# Altium schematic sheet DE-130624.SchDoc(DE-130624)
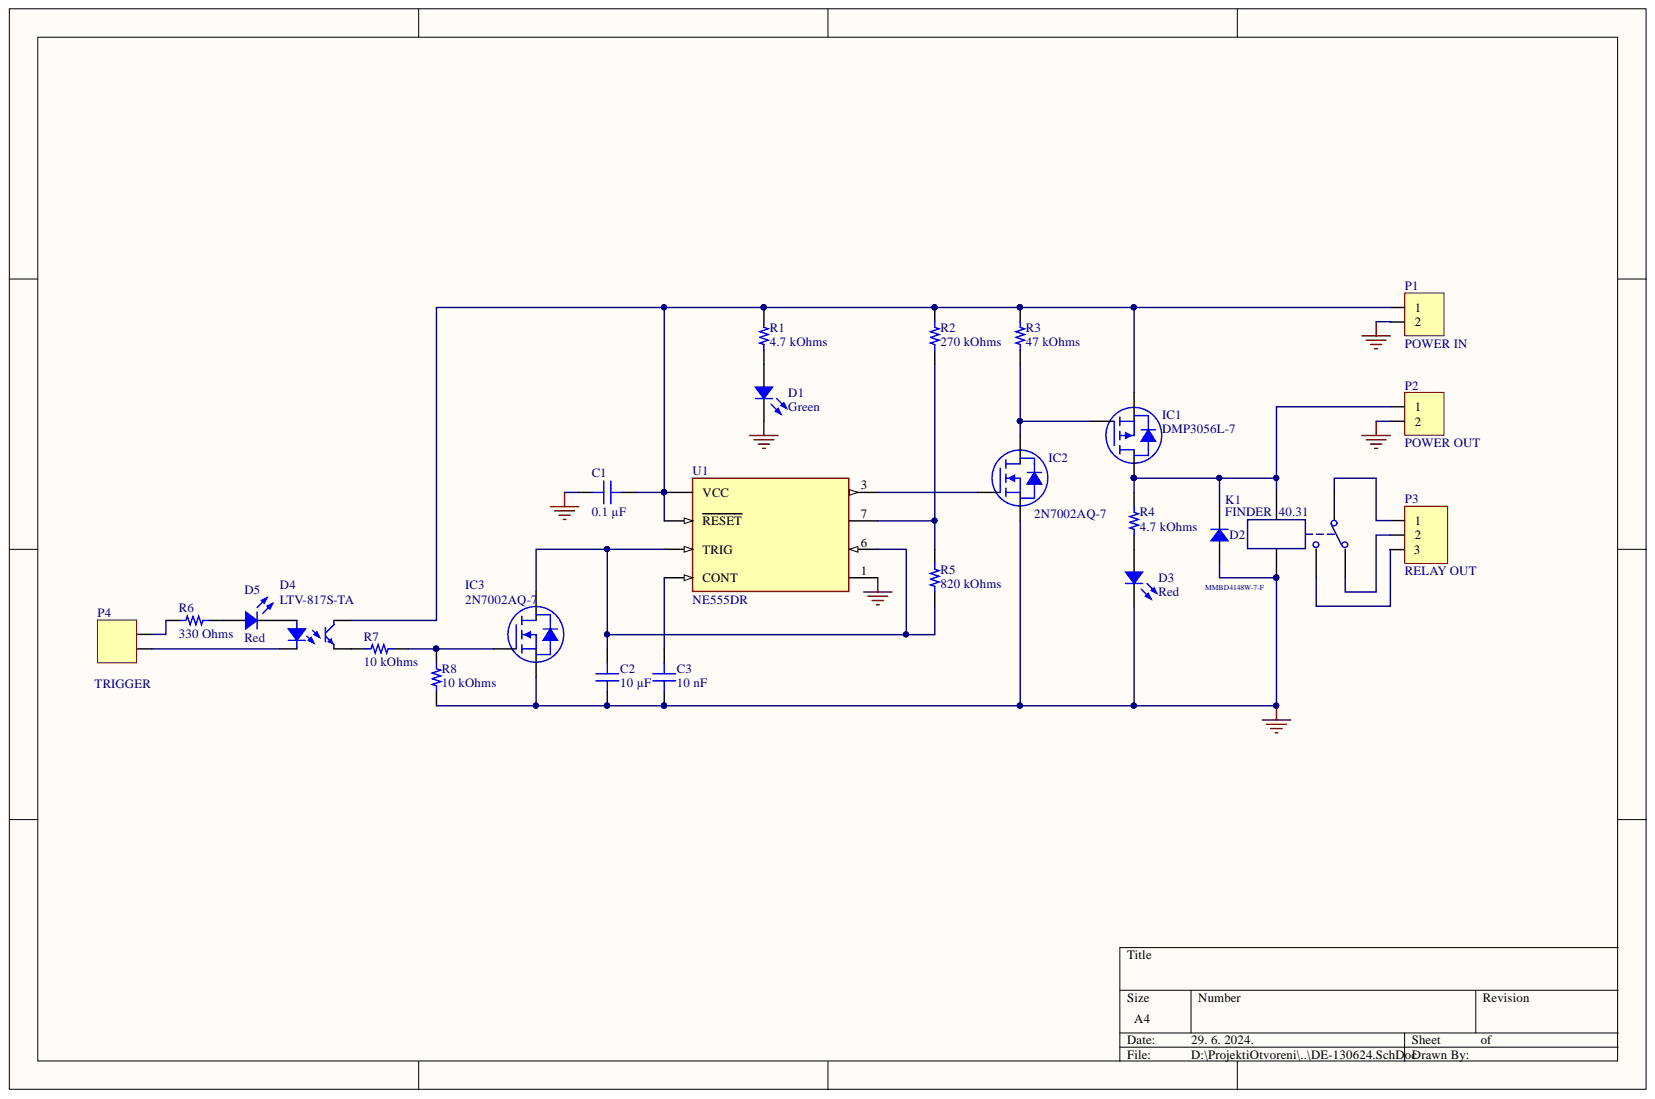
[diagram p0: DE-130624.SchDoc]

## Parts
C1  0.1 µF  [CAP 0603_1608]
C2  10 µF  [CAP 0805_2012]
C3  10 nF  [CAP 0603_1608]
D1  Green  [1.6X0.8X0.8]
D2  MMBD4148W-7-F  [DIODES SOT-323-3]
D3  Red  [1.6X0.8X0.8]
D4  LTV-817S-TA  [LITEON LTV-817]
D5  Red  [1.6X0.8X0.8]
IC1  DMP3056L-7  [DIODES SOT-23-3]
IC2  2N7002AQ-7  [DIODES SOT-23-3]
IC3  2N7002AQ-7  [DIODES SOT-23-3]
K1  FINDER  40.31  [FINDER 40.31]
P1  POWER IN  [TERMINAL BLOK 2]
P2  POWER OUT  [TERMINAL BLOK 2]
P3  RELAY OUT  [TERMINAL BLOK 3]
P4  TRIGGER  [TERMINAL BLOK 2]
R1  4.7 kOhms  [RES 0805_2012]
R2  270 kOhms  [RES 0805_2012]
R3  47 kOhms  [RES 0805_2012]
R4  4.7 kOhms  [RES 0805_2012]
R5  820 kOhms  [RES 0805_2012]
R6  330 Ohms  [RES 0805_2012]
R7  10 kOhms  [RES 0805_2012]
R8  10 kOhms  [RES 0805_2012]
U1  NE555DR  [FP-D0008A-IPC_B]

## Nets
GND: C1.1 C2.1 C3.1 D1.2 D2.1 D3.2 IC2.2 IC3.2 K1.2 P1.2 P2.2 R8.1 U1.1
NetC1_2: C1.2 D4.4 IC1.2 P1.1 R1.2 R2.2 R3.2 U1.4(RESET) U1.8(VCC)
NetC2_2: C2.2 IC3.3 R5.1 U1.2(TRIG) U1.6
NetC3_2: C3.2 U1.5(CONT)
NetD1_1: D1.1 R1.1
NetD2_2: D2.2 IC1.3 K1.1 P2.1 R4.2
NetD3_1: D3.1 R4.1
NetD4_1: D4.1 D5.2
NetD4_2: D4.2 P4.1
NetD4_3: D4.3 R7.2
NetD5_1: D5.1 R6.2
NetIC1_1: IC1.1 IC2.3 R3.1
NetIC2_1: IC2.1 U1.3
NetIC3_1: IC3.1 R7.1 R8.2
NetK1_3: K1.3 P3.1
NetK1_4: K1.4 P3.3
NetK1_5: K1.5 P3.2
NetP4_2: P4.2 R6.1
NetR2_1: R2.1 R5.2 U1.7

## Library data of the parts
C1 = C0603C104K5RAC7411: CAP CER 0.1UF 50V X7R 0603; manufacturer: KEMET; Tolerance: ±10%; Database Table Name: Capacitors - Ceramic - 0603; Voltage - Rated: 50V; Value: 0.1 µF; Thickness (Max): 0.035" (0.90mm); Temperature Coefficient: X7R; Supplier Part Number 1: 399-C0603C104K5RAC7411CT-ND; Supplier 1: DigiKey; Size / Dimension: 0.063" L x 0.031" W (1.60mm x 0.80mm); series: SMD Comm X7R; price: 0.01; Part Status: Active; Part Number: C0603C104K5RAC7411; partid: 2233; packaging: TapeAndReel; Package / Case: 0603 (1608 Metric); Operating Temperature: -55°C ~ 125°C; Mounting Type: Surface Mount, MLCC; Minimum Order: 1; Lifecycle Status: Active; Library Name: Celestial Altium Library - altium_library.DbLib; lastupdated: 9. 2. 2024. 20:10:30; Device Package: 0603 (1608 Metric); componentlink2url: https://www.digikey.com/en/products/detail/kemet/C0603C104K5RAC7411/3317013; componentlink2description: DigiKey Link; componentlink1url: https://api.kemet.com/component-edge/download/datasheet/C0603C104K5RAC7411.pdf; componentlink1description: Datasheet; Component Kind: Standard; Capacitance: 0.1 µF; Applications: Bypass, Decoupling
C2 = GMK212BBJ106KG-T: CAP CER 10UF 35V X5R 0805; manufacturer: Taiyo Yuden; Tolerance: ±10%; Database Table Name: Capacitors - Ceramic - 0805; Voltage - Rated: 35V; Value: 10 µF; Thickness (Max): 0.057" (1.45mm); Temperature Coefficient: X5R; Supplier Part Number 1: 587-4893-1-ND; Supplier 1: DigiKey; Size / Dimension: 0.079" L x 0.049" W (2.00mm x 1.25mm); series: M; price: 0.15; Part Status: Active; Part Number: GMK212BBJ106KG-T; partid: 11657; packaging: TapeAndReel; Package / Case: 0805 (2012 Metric); Operating Temperature: -55°C ~ 85°C; Mounting Type: Surface Mount, MLCC; Minimum Order: 1; Lifecycle Status: Active; Library Name: Celestial Altium Library - altium_library.DbLib; lastupdated: 9. 2. 2024. 20:15:45; Device Package: 0805 (2012 Metric); componentlink2url: https://www.digikey.com/en/products/detail/taiyo-yuden/GMK212BBJ106KG-T/6563486; componentlink2description: DigiKey Link; componentlink1url: http://www.yuden.co.jp/productdata/catalog/mlcc06_e.pdf; componentlink1description: Datasheet; Component Kind: Standard; Capacitance: 10 µF; Applications: SMPS Filtering
C3 = C0603X103K1RAC3316: CAP CER 0603 10NF 100V X7R; manufacturer: KEMET; Tolerance: ±10%; Database Table Name: Capacitors - Ceramic - 0603; Capacitance: 10000 pF; Voltage - Rated: 100V; Value: 10 nF; Thickness (Max): 0.037" (0.95mm); Temperature Coefficient: X7R; Supplier Part Number 1: 399-C0603X103K1RAC3316CT-ND; Supplier 1: DigiKey; Size / Dimension: 0.063" L x 0.031" W (1.60mm x 0.80mm); series: SMD Auto X7R VW80808; Ratings: AEC-Q200; price: 0.07; Part Status: Active; Part Number: C0603X103K1RAC3316; partid: 8591; packaging: TapeAndReel; Package / Case: 0603 (1608 Metric); Operating Temperature: -55°C ~ 125°C; Mounting Type: Surface Mount, MLCC; Minimum Order: 1; Lifecycle Status: Active; Library Name: Celestial Altium Library - altium_library.DbLib; lastupdated: 9. 2. 2024. 20:10:22; Features: Soft Termination; Device Package: 0603 (1608 Metric); componentlink2url: https://www.digikey.com/en/products/detail/kemet/C0603X103K1RAC3316/10315782; componentlink2description: DigiKey Link; componentlink1url: https://api.kemet.com/component-edge/download/datasheet/C0603X103K1RAC3316.pdf; componentlink1description: Datasheet; Component Kind: Standard; Applications: Automotive, Boardflex Sensitive
D1 = LTST-C170KGKT: LED GREEN CLEAR SMD; Manufacturer: Lite-On Inc.; Wavelength - Peak: 574nm; Wavelength - Dominant: 571nm; Voltage - Forward (Vf) (Typ): 2V; Viewing Angle: 130°; Millicandela Rating: 35mcd; Lens Style: Rectangle with Flat Top; Lens Size: 1.40mm x 1.25mm; Lens Color: Colorless; Database Table Name: LED - Indication; Current - Test: 20mA; Configuration: Standard; Value: Green; Supplier Part Number 1: 160-1414-1-ND; Supplier 1: DigiKey; Size / Dimension: 2.00mm L x 1.25mm W; Series: -; Price: 0.06; Part Status: Active; Part Number: LTST-C170KGKT; PartId: 285; Packaging: TapeAndReel; Package / Case: 0805 (2012 Metric); Mounting Type: Surface Mount; Minimum Order: 1; Lifecycle Status: Active; Library Name: Celestial Altium Library - altium_library.DbLib; Lens Transparency: Clear; LastUpdated: 3. 6. 2024. 20:00:29; Height (Max): 1.10mm; Device Package: 0805 (2012 Metric); ComponentLink2URL: http://digikey.com/product-detail/en/lite-on-inc/LTST-C170KGKT/160-1414-1-ND/386776; ComponentLink2Description: DigiKey Link; ComponentLink1URL: http://optoelectronics.liteon.com/upload/download/DS22-2000-073/LTST-C170KGKT.pdf; ComponentLink1Description: Datasheet; Component Kind: Standard; Color: Green
D2 = MMBD4148W-7-F: DIODE GEN PURP 75V 150MA SOT323; Manufacturer: Diodes Incorporated; Voltage - Forward (Vf) (Max) @ If: 1.25 V @ 150 mA; Speed: Small Signal =< 200mA (Io), Any Speed; Reverse Recovery Time (trr): 4 ns; Diode Type: Standard; Database Table Name: Diodes - Rectifiers - Single; Current - Reverse Leakage @ Vr: 1 µA @ 75 V; Capacitance @ Vr, F: 2pF @ 0V, 1MHz; Voltage - DC Reverse (Vr) (Max): 75 V; Supplier Part Number 1: MMBD4148W-FDICT-ND; Supplier Device Package: SOT-323; Supplier 1: DigiKey; Series: -; Price: 0.02; Part Status: Active; Part Number: MMBD4148W-7-F; PartId: 13; Packaging: TapeAndReel; Package / Case: SC-70, SOT-323; Operating Temperature - Junction: -65°C ~ 150°C; Mounting Type: Surface Mount; Minimum Order: 1; Lifecycle Status: Active; Library Name: Celestial Altium Library - altium_library.DbLib; LastUpdated: 3. 6. 2024. 20:01:39; Current - Average Rectified (Io): 150mA; ComponentLink2URL: https://www.digikey.com/en/products/detail/diodes-incorporated/MMBD4148W-7-F/768787; ComponentLink2Description: DigiKey Link; ComponentLink1URL: https://www.diodes.com/assets/Datasheets/ds30094.pdf; ComponentLink1Description: Datasheet; Component Kind: Standard
D3 D5 = LTST-C170KAKT: LED RED CLEAR SMD; Manufacturer: Lite-On Inc.; Wavelength - Peak: 621nm; Wavelength - Dominant: 615nm; Voltage - Forward (Vf) (Typ): 2V; Viewing Angle: 130°; Millicandela Rating: 54mcd; Lens Style: Rectangle with Flat Top; Lens Size: 1.40mm x 1.25mm; Lens Color: Colorless; Database Table Name: LED - Indication; Current - Test: 20mA; Configuration: Standard; Value: Red; Supplier Part Number 1: 160-1412-1-ND; Supplier 1: DigiKey; Size / Dimension: 2.00mm L x 1.25mm W; Series: -; Price: 0.07; Part Status: Active; Part Number: LTST-C170KAKT; PartId: 305; Packaging: TapeAndReel; Package / Case: 0805 (2012 Metric); Mounting Type: Surface Mount; Minimum Order: 1; Lifecycle Status: Active; Library Name: Celestial Altium Library - altium_library.DbLib; Lens Transparency: Clear; LastUpdated: 3. 6. 2024. 20:00:07; Height (Max): 1.10mm; Device Package: 0805 (2012 Metric); ComponentLink2URL: http://digikey.com/product-detail/en/lite-on-inc/LTST-C170KAKT/160-1412-1-ND/386772; ComponentLink2Description: DigiKey Link; ComponentLink1URL: http://optoelectronics.liteon.com/upload/download/DS-22-99-0182/LTST-C170KAKT.pdf; ComponentLink1Description: Datasheet; Component Kind: Standard; Color: Red
D4 = LTV-817S-TA: OPTOISOLATR 5KV TRANSISTOR 4-SMD; Manufacturer: Lite-On Inc.; Voltage - Output (Max): 35V; Voltage - Isolation: 5000Vrms; Voltage - Forward (Vf) (Typ): 1.2V; Vce Saturation (Max): 200mV; Rise / Fall Time (Typ): 4µs, 3µs; Number of Channels: 1; Input Type: DC; Database Table Name: Optoisolators - Transistor Photovoltaic; Current Transfer Ratio (Min): 50% @ 5mA; Current Transfer Ratio (Max): 600% @ 5mA; Current - Output / Channel: 50mA; Supplier Part Number 1: 160-2038-1-ND; Supplier Device Package: 4-SMD; Supplier 1: DigiKey; Series: -; Price: 0.12; Part Status: Active; Part Number: LTV-817S-TA; Packaging: TapeAndReel; Package / Case: 4-SMD, Gull Wing; Output Type: Transistor; Operating Temperature: -30°C ~ 110°C; Mounting Type: Surface Mount; Minimum Order: 1; Lifecycle Status: Active; Library Name: Celestial Altium Library - altium_library.DbLib; LastUpdated: 12. 1. 2020. 21:59:02; Device Package: 4-SMD, Gull Wing; Current - DC Forward (If) (Max): 50mA; ComponentLink2URL: http://digikey.com/product-detail/en/lite-on-inc/LTV-817S-TA/160-2038-1-ND/3711420; ComponentLink2Description: DigiKey Link; ComponentLink1URL: http://optoelectronics.liteon.com/upload/download/DS-70-96-0016/LTV-8X7%20series%20201610%20.pdf; ComponentLink1Description: Datasheet; Component Kind: Standard
IC1 = DMP3056L-7: MOSFET P-CH 30V 4.3A SOT23; Manufacturer: Diodes Incorporated; Vgs(th) (Max) @ Id: 2.1V @ 250µA; Vgs (Max): ±25V; Rds On (Max) @ Id, Vgs: 50mOhm @ 6A, 10V; Power Dissipation (Max): 1.38W (Ta); Input Capacitance (Ciss) (Max) @ Vds: 642 pF @ 25 V; Gate Charge (Qg) (Max) @ Vgs: 11.8 nC @ 10 V; FET Type: P-Channel; Drive Voltage (Max Rds On,  Min Rds On): 4.5V, 10V; Database Table Name: MOSFET - Single - P-Ch; Current - Continuous Drain (Id) @ 25°C: 4.3A (Ta); Technology: MOSFET (Metal Oxide); Supplier Part Number 1: DMP3056L-7DICT-ND; Supplier Device Package: SOT-23-3; Supplier 1: DigiKey; Series: -; Price: 0.09; Part Status: Active; Part Number: DMP3056L-7; Packaging: TapeAndReel; Package / Case: TO-236-3, SC-59, SOT-23-3; Operating Temperature: -55°C ~ 150°C (TJ); Mounting Type: Surface Mount; Minimum Order: 1; Lifecycle Status: Active; Library Name: Celestial Altium Library - altium_library.DbLib; LastUpdated: 3. 6. 2024. 20:15:20; Drain to Source Voltage (Vdss): 30 V; ComponentLink2URL: https://www.digikey.com/en/products/detail/diodes-incorporated/DMP3056L-7/5126843; ComponentLink2Description: DigiKey Link; ComponentLink1URL: https://www.diodes.com/assets/Datasheets/DMP3056L.pdf; ComponentLink1Description: Datasheet; Component Kind: Standard
IC2 IC3 = 2N7002AQ-7: MOSFET N-CH 60V 180MA SOT23; Manufacturer: Diodes Incorporated; Vgs(th) (Max) @ Id: 2V @ 250µA; Vgs (Max): ±20V; Rds On (Max) @ Id, Vgs: 5Ohm @ 115mA, 10V; Power Dissipation (Max): 370mW (Ta); Input Capacitance (Ciss) (Max) @ Vds: 23 pF @ 25 V; FET Type: N-Channel; Drive Voltage (Max Rds On,  Min Rds On): 5V; Database Table Name: MOSFET - Single - N-Ch; Current - Continuous Drain (Id) @ 25°C: 180mA (Ta); Technology: MOSFET (Metal Oxide); Supplier Part Number 1: 2N7002AQ-7DICT-ND; Supplier Device Package: SOT-23-3; Supplier 1: DigiKey; Series: -; Price: 0.03; Part Status: Active; Part Number: 2N7002AQ-7; Packaging: TapeAndReel; Package / Case: TO-236-3, SC-59, SOT-23-3; Operating Temperature: -55°C ~ 150°C (TJ); Mounting Type: Surface Mount; Minimum Order: 1; Lifecycle Status: Active; Library Name: Celestial Altium Library - altium_library.DbLib; LastUpdated: 3. 6. 2024. 20:15:18; Drain to Source Voltage (Vdss): 60 V; ComponentLink2URL: https://www.digikey.com/en/products/detail/diodes-incorporated/2N7002AQ-7/6186937; ComponentLink2Description: DigiKey Link; ComponentLink1URL: https://www.diodes.com/assets/Datasheets/2N7002AQ.pdf; ComponentLink1Description: Datasheet; Component Kind: Standard
K1 = FINDER RELAY 40.31: Library Name: DE-220817.SchLib; Component Kind: Standard
P1 P2 P4 = TERMINAL BLOK 2: Terminal blok konektor 3,5 mm; Library Name: DE-220817.SchLib; Component Kind: Standard
P3 = TERMINAL BLOK 3: 3 pinski terminal blok konektor; Library Name: DE-220817.SchLib; Component Kind: Standard
R1 R4 = AC0805FR-7W4K7L: RES 4.7K OHM 1% 1/4W 0805; Manufacturer: Yageo; Temperature Coefficient: ±100ppm/°C; Power (Watts): 0.25W, 1/4W; Database Table Name: Resistors - Surface Mount - 0805; Value: 4.7 kOhms; Tolerance: ±1%; Supplier Part Number 1: 13-AC0805FR-7W4K7LCT-ND; Supplier Device Package: 0805; Supplier 1: DigiKey; Size / Dimension: 0.079" L x 0.049" W (2.00mm x 1.25mm); Series: AC; Resistance: 4.7 kOhms; Ratings: AEC-Q200; Price: 0.01; Part Status: Active; Part Number: AC0805FR-7W4K7L; PartId: 77750; Packaging: TapeAndReel; Package / Case: 0805 (2012 Metric); Operating Temperature: -55°C ~ 155°C; Number of Terminations: 2; Minimum Order: 1; Lifecycle Status: Active; Library Name: Celestial Altium Library - altium_library.DbLib; LastUpdated: 8. 2. 2024. 21:00:02; Height - Seated (Max): 0.024" (0.60mm); Features: Automotive AEC-Q200, Moisture Resistant; Device Package: 0805 (2012 Metric); Composition: Thick Film; ComponentLink2URL: https://www.digikey.com/en/products/detail/yageo/AC0805FR-7W4K7L/16989051; ComponentLink2Description: DigiKey Link; ComponentLink1URL: https://www.yageo.com/upload/media/product/productsearch/datasheet/rchip/PYu-AC_51_RoHS_L_9.pdf; ComponentLink1Description: Datasheet; Component Kind: Standard
R2 = AC0805FR-07270KL: RES SMD 270K OHM 1% 1/8W 0805; Manufacturer: Yageo; Temperature Coefficient: ±100ppm/°C; Power (Watts): 0.125W, 1/8W; Database Table Name: Resistors - Surface Mount - 0805; Value: 270 kOhms; Tolerance: ±1%; Supplier Part Number 1: 13-AC0805FR-07270KLCT-ND; Supplier Device Package: 0805; Supplier 1: DigiKey; Size / Dimension: 0.079" L x 0.049" W (2.00mm x 1.25mm); Series: AC; Resistance: 270 kOhms; Ratings: AEC-Q200; Price: 0.01; Part Status: Active; Part Number: AC0805FR-07270KL; PartId: 61828; Packaging: TapeAndReel; Package / Case: 0805 (2012 Metric); Operating Temperature: -55°C ~ 155°C; Number of Terminations: 2; Minimum Order: 1; Lifecycle Status: Active; Library Name: Celestial Altium Library - altium_library.DbLib; LastUpdated: 8. 2. 2024. 21:00:40; Height - Seated (Max): 0.024" (0.60mm); Features: Automotive AEC-Q200, Moisture Resistant; Device Package: 0805 (2012 Metric); Composition: Thick Film; ComponentLink2URL: https://www.digikey.com/en/products/detail/yageo/AC0805FR-07270KL/5896709; ComponentLink2Description: DigiKey Link; ComponentLink1URL: https://www.yageo.com/upload/media/product/productsearch/datasheet/rchip/PYu-AC_51_RoHS_L_8.pdf; ComponentLink1Description: Datasheet; Component Kind: Standard
R3 = AC0805FR-0747KL: RES SMD 47K OHM 1% 1/8W 0805; Manufacturer: Yageo; Temperature Coefficient: ±100ppm/°C; Power (Watts): 0.125W, 1/8W; Database Table Name: Resistors - Surface Mount - 0805; Value: 47 kOhms; Tolerance: ±1%; Supplier Part Number 1: YAG3735CT-ND; Supplier Device Package: 0805; Supplier 1: DigiKey; Size / Dimension: 0.079" L x 0.049" W (2.00mm x 1.25mm); Series: AC; Resistance: 47 kOhms; Ratings: AEC-Q200; Price: 0.01; Part Status: Active; Part Number: AC0805FR-0747KL; PartId: 34676; Packaging: TapeAndReel; Package / Case: 0805 (2012 Metric); Operating Temperature: -55°C ~ 155°C; Number of Terminations: 2; Minimum Order: 1; Lifecycle Status: Active; Library Name: Celestial Altium Library - altium_library.DbLib; LastUpdated: 8. 2. 2024. 21:02:08; Height - Seated (Max): 0.024" (0.60mm); Features: Automotive AEC-Q200, Moisture Resistant; Device Package: 0805 (2012 Metric); Composition: Thick Film; ComponentLink2URL: https://www.digikey.com/en/products/detail/yageo/AC0805FR-0747KL/5896858; ComponentLink2Description: DigiKey Link; ComponentLink1URL: https://www.yageo.com/upload/media/product/productsearch/datasheet/rchip/PYu-AC_51_RoHS_L_7.pdf; ComponentLink1Description: Datasheet; Component Kind: Standard
R5 = AC0805FR-07820KL: RES SMD 820K OHM 1% 1/8W 0805; Manufacturer: Yageo; Temperature Coefficient: ±100ppm/°C; Power (Watts): 0.125W, 1/8W; Database Table Name: Resistors - Surface Mount - 0805; Value: 820 kOhms; Tolerance: ±1%; Supplier Part Number 1: YAG5381CT-ND; Supplier Device Package: 0805; Supplier 1: DigiKey; Size / Dimension: 0.079" L x 0.049" W (2.00mm x 1.25mm); Series: AC; Resistance: 820 kOhms; Ratings: AEC-Q200; Price: 0.01; Part Status: Active; Part Number: AC0805FR-07820KL; PartId: 49554; Packaging: TapeAndReel; Package / Case: 0805 (2012 Metric); Operating Temperature: -55°C ~ 155°C; Number of Terminations: 2; Minimum Order: 1; Lifecycle Status: Active; Library Name: Celestial Altium Library - altium_library.DbLib; LastUpdated: 8. 2. 2024. 21:00:48; Height - Seated (Max): 0.024" (0.60mm); Features: Automotive AEC-Q200, Moisture Resistant; Device Package: 0805 (2012 Metric); Composition: Thick Film; ComponentLink2URL: https://www.digikey.com/en/products/detail/yageo/AC0805FR-07820KL/5896994; ComponentLink2Description: DigiKey Link; ComponentLink1URL: https://www.yageo.com/upload/media/product/productsearch/datasheet/rchip/PYu-AC_51_RoHS_L_7.pdf; ComponentLink1Description: Datasheet; Component Kind: Standard
R6 = AT0805FRE071KL: RES 1K OHM 1% 1/8W 0805; Manufacturer: Yageo; Temperature Coefficient: ±50ppm/°C; Resistance: 1 kOhms; Power (Watts): 0.125W, 1/8W; Database Table Name: Resistors - Surface Mount - 0805; Value: 330 Ohms; Tolerance: ±1%; Supplier Part Number 1: 13-AT0805FRE071KLCT-ND; Supplier Device Package: 0805; Supplier 1: DigiKey; Size / Dimension: 0.079" L x 0.049" W (2.00mm x 1.25mm); Series: AT; Ratings: AEC-Q200; Price: 0.09; Part Number: AT0805FRE071KL; PartId: 81015; Packaging: TapeAndReel; Package / Case: 0805 (2012 Metric); Operating Temperature: -55°C ~ 155°C; Number of Terminations: 2; Minimum Order: 1; Lifecycle Status: Active; Library Name: Celestial Altium Library - altium_library.DbLib; LastUpdated: 8. 2. 2024. 20:59:53; Height - Seated (Max): 0.024" (0.60mm); Features: Anti-Sulfur, Automotive AEC-Q200, Moisture Resistant; Device Package: 0805 (2012 Metric); Composition: Thin Film; ComponentLink2URL: https://www.digikey.com/en/products/detail/yageo/AT0805FRE071KL/16991952; ComponentLink2Description: DigiKey Link; ComponentLink1URL: https://www.yageo.com/upload/media/product/productsearch/datasheet/rchip/PYu-AT_51_RoHS_L_6.pdf; ComponentLink1Description: Datasheet; Component Kind: Standard
R7 = AA0805FR-0710KL: RES SMD 10K OHM 1% 1/8W 0805; Manufacturer: Yageo; Temperature Coefficient: ±150ppm/°C; Power (Watts): 0.125W, 1/8W; Database Table Name: Resistors - Surface Mount - 0805; Value: 10 kOhms; Tolerance: ±1%; Supplier Part Number 1: 13-AA0805FR-0710KLCT-ND; Supplier Device Package: 0805; Supplier 1: DigiKey; Size / Dimension: 0.079" L x 0.049" W (2.00mm x 1.25mm); Series: AA; Resistance: 10 kOhms; Ratings: AEC-Q200; Price: 0.01; Part Status: Active; Part Number: AA0805FR-0710KL; PartId: 61824; Packaging: TapeAndReel; Package / Case: 0805 (2012 Metric); Operating Temperature: -55°C ~ 155°C; Number of Terminations: 2; Minimum Order: 1; Lifecycle Status: Active; Library Name: Celestial Altium Library - altium_library.DbLib; LastUpdated: 8. 2. 2024. 21:00:40; Height - Seated (Max): 0.024" (0.60mm); Features: Anti-Sulfur, Automotive AEC-Q200, Moisture Resistant; Device Package: 0805 (2012 Metric); Composition: Thick Film; ComponentLink2URL: https://www.digikey.com/en/products/detail/yageo/AA0805FR-0710KL/5891935; ComponentLink2Description: DigiKey Link; ComponentLink1URL: https://www.yageo.com/upload/media/product/productsearch/datasheet/rchip/PYu-AA_51_RoHS_L_3.pdf; ComponentLink1Description: Datasheet; Component Kind: Standard
R8 = AC0805FR-0727KL: RES SMD 27K OHM 1% 1/8W 0805; Manufacturer: Yageo; Temperature Coefficient: ±100ppm/°C; Resistance: 27 kOhms; Power (Watts): 0.125W, 1/8W; Database Table Name: Resistors - Surface Mount - 0805; Value: 10 kOhms; Tolerance: ±1%; Supplier Part Number 1: 13-AC0805FR-0727KLCT-ND; Supplier Device Package: 0805; Supplier 1: DigiKey; Size / Dimension: 0.079" L x 0.049" W (2.00mm x 1.25mm); Series: AC; Ratings: AEC-Q200; Price: 0.01; Part Status: Active; Part Number: AC0805FR-0727KL; PartId: 66664; Packaging: TapeAndReel; Package / Case: 0805 (2012 Metric); Operating Temperature: -55°C ~ 155°C; Number of Terminations: 2; Minimum Order: 1; Lifecycle Status: Active; Library Name: Celestial Altium Library - altium_library.DbLib; LastUpdated: 8. 2. 2024. 21:00:29; Height - Seated (Max): 0.024" (0.60mm); Features: Automotive AEC-Q200, Moisture Resistant; Device Package: 0805 (2012 Metric); Composition: Thick Film; ComponentLink2URL: https://www.digikey.com/en/products/detail/yageo/AC0805FR-0727KL/5896714; ComponentLink2Description: DigiKey Link; ComponentLink1URL: https://www.yageo.com/upload/media/product/productsearch/datasheet/rchip/PYu-AC_51_RoHS_L_8.pdf; ComponentLink1Description: Datasheet; Component Kind: Standard
U1 = CMP-1685-00007-2: IC OSC SGL TIMER 100KHZ 8-SOIC; Quiescent Current: 15mA; Operating Supply Current: 10mA; Number of Timers/Counters: 1; Min Supply Voltage: 4.5V; Max Supply Voltage: 16V; Low Level Output Current: 200mA; High Level Output Current: -200mA; Width: 3.91mm; Weight: 0.002561oz; REACH SVHC: No SVHC; Radiation Hardening: No; Packaging: Tape and Reel; Package Quantity: 2500; Number of Pins: 8; Mount: Surface Mount; Min Operating Temperature: 0°C; Max Operating Temperature: 70°C; Library Name: Components - 13.06.24 - 15.35.SchLib; Length: 4.9mm; Lead Free: Lead Free; Height: 1.58mm; Frequency: 100kHz; Contact Plating: Gold; Component Kind: Standard; Case/Package: SOIC
